# Altium schematic sheet INPUT AND OUPUT.SchDoc
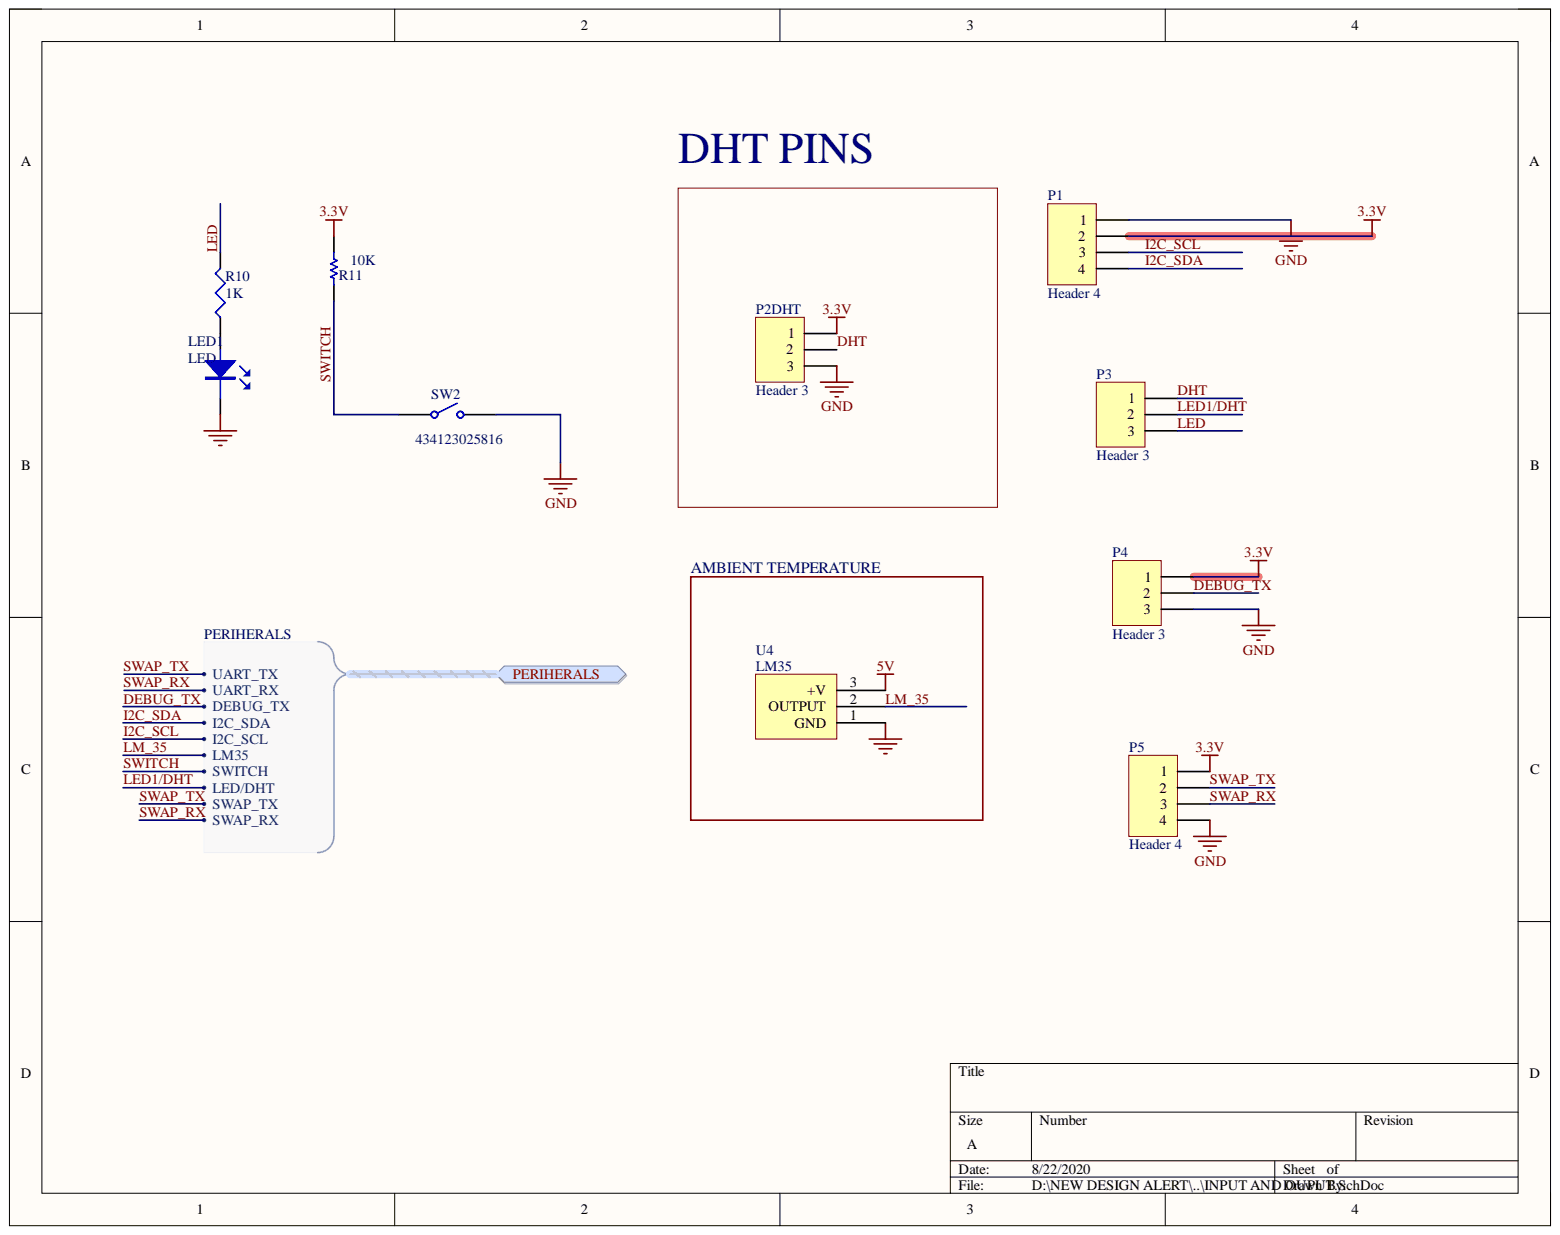
[diagram p0: INPUT AND OUPUT.SchDoc]

## Parts
LED1  LED  [Type 3mm]
P1  Header 4  [HDR1X4]
P2DHT  Header 3  [HDR1X3]
P3  Header 3  [HDR1X3]
P4  Header 3  [HDR1X3]
P5  Header 4  [HDR1X4]
R10  1K  [RESC0603]
R11  10K  [RESC0603]
SW2  434123025816  [434123025816]
U4  LM35  [TO92S]

## Ports
PERIHERALS, PERIHERALS.DEBUG_TX, PERIHERALS.I2C_SCL, PERIHERALS.I2C_SDA, PERIHERALS.LED/DHT, PERIHERALS.LM35, PERIHERALS.SWAP_RX, PERIHERALS.SWAP_TX, PERIHERALS.SWITCH, PERIHERALS.UART_RX, PERIHERALS.UART_TX

## Nets
3.3V: P1.2 P2DHT.1 P4.1 P5.1 R11.2
5V: U4.3
GND: LED1.1 P1.1 P2DHT.3 P4.3 P5.4 SW2.2 U4.1
DEBUG_TX: P4.2 ; port PERIHERALS
DHT: P2DHT.2 P3.1
I2C_SCL: P1.3 ; port PERIHERALS
I2C_SDA: P1.4 ; port PERIHERALS
LED: P3.3 R10.2
LED1/DHT: P3.2 ; port PERIHERALS
LM_35: U4.2 ; port PERIHERALS
SWAP_RX: P5.3 ; port PERIHERALS
SWAP_TX: P5.2 ; port PERIHERALS
SWITCH: R11.1 SW2.1 ; port PERIHERALS
NetLED1_2: LED1.2 R10.1
N00001: ; port PERIHERALS
N00002: ; port PERIHERALS

## Library data of the parts
P1 P5 = Header 4: Header, 4-Pin; Component Kind: Standard; LatestRevisionDate: 17-Jul-2002; LatestRevisionNote: Re-released for DXP Platform.; Library Name: Miscellaneous Connectors.IntLib; Signal Integrity: Connector; Publisher: Altium Limited
P2DHT P3 P4 = Header 3: Header, 3-Pin; Component Kind: Standard; LatestRevisionDate: 17-Jul-2002; LatestRevisionNote: Re-released for DXP Platform.; Library Name: Miscellaneous Connectors.IntLib; Signal Integrity: Connector; Publisher: Altium Limited
R10 = RES1: RESISTOR; Component Kind: Standard; Library Name: Devices.SchLib
R11 = CMP-1013-00215-1: 2R55 0.125W 1% 0805 (2012 Metric)  SMD; Case-EIA: 0805; Case-Metric: 2012; Value: 2.55 Ohm; Component Kind: Standard; Library Name: Components - 22.01.19 - 11.39.SchLib; Power: 0.125 W; Technology: SMT; Tolerance: 1 %
SW2 = CMP-1460-00004-1: WS-TASV J-Bend SMD Tact Switch 6.0X3.8 mm; Manufacturer: Wuerth Elektronik; NoPins: 02; Component Kind: Standard; ComponentLink1Description: Manufacturer URL; ComponentLink1URL: http://www.we-online.com; ComponentLink2Description: Datasheet; ComponentLink2URL: http://katalog.we-online.de/em/datasheet/434123025816.pdf; Library Name: Altium Content Vault; PackageReference: 434123025816; PartNumber: 434123025816
LED1 = LED: Component Kind: Standard; Library Name: Devices.SchLib
U4 = LM35: Component Kind: Standard; Library Name: CustomParts.SchLib
